# Altium schematic sheet Interfaces.SchDoc
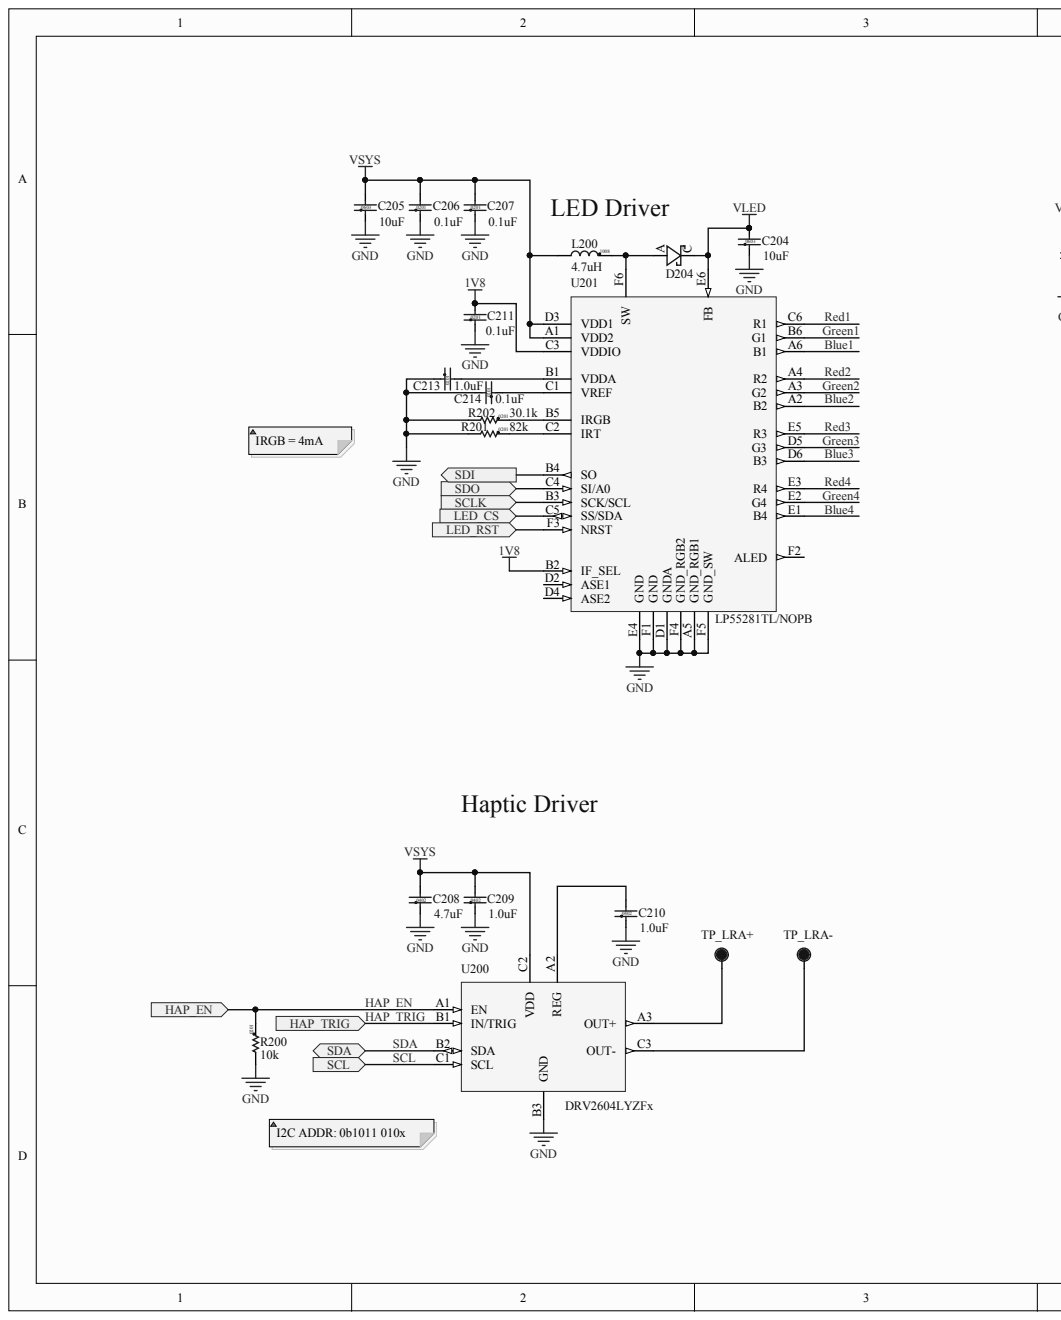
[diagram p0: Interfaces.SchDoc, left]
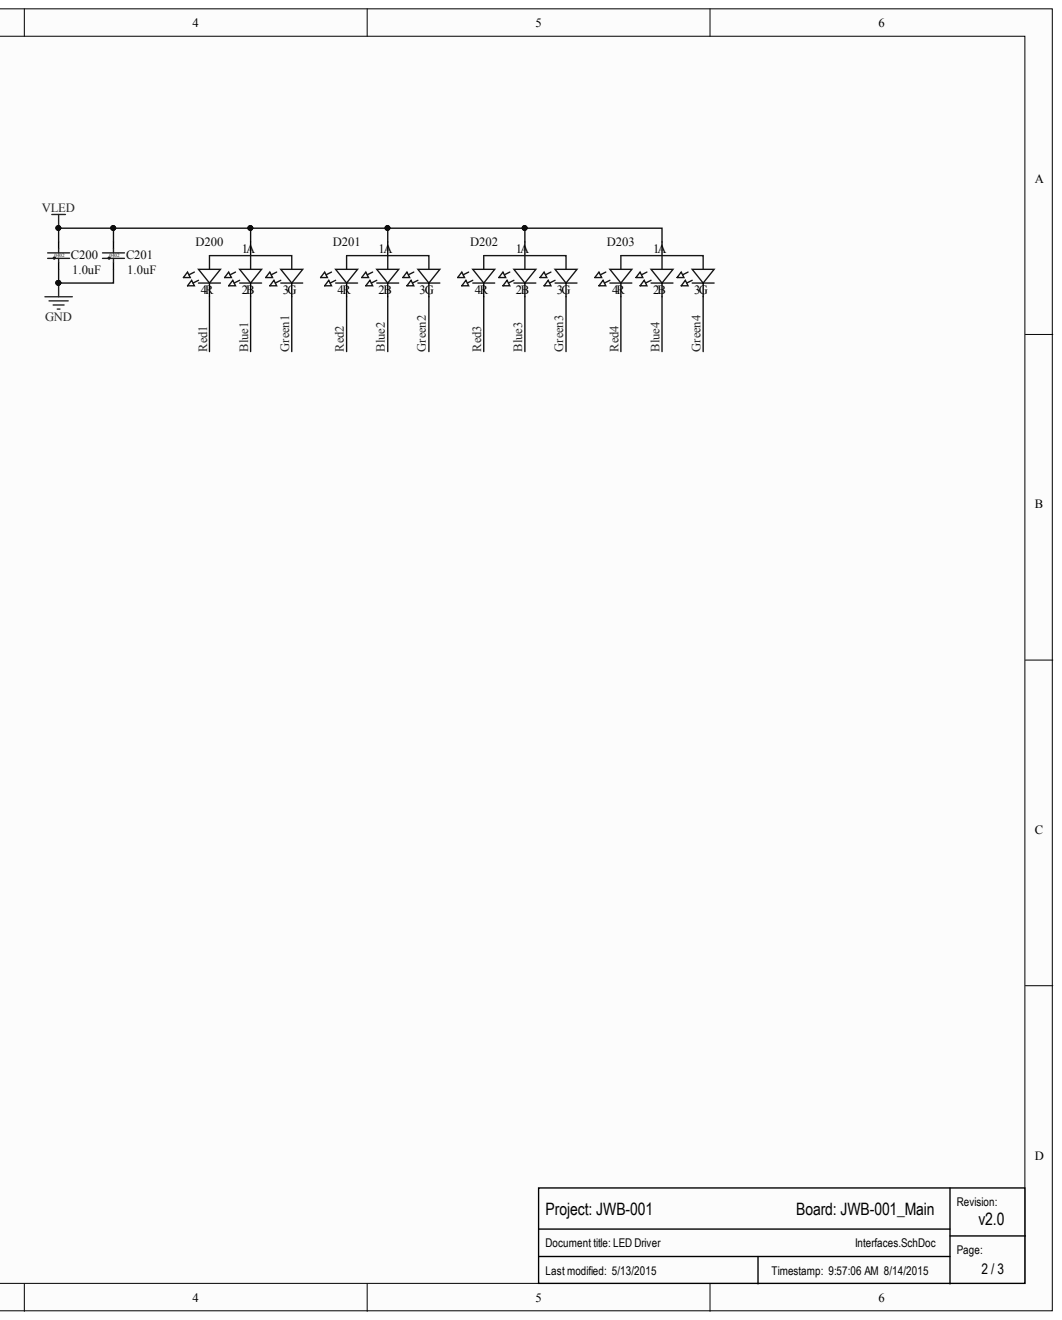
[diagram p1: Interfaces.SchDoc, right]

## Parts
C200  value 1.0uF  Size: 0402  [Cap 0402 HD]  (hidden comment: Cap 0402 1.0uF 10V1.0uF)
C201  value 1.0uF  Size: 0402  [Cap 0402 HD]  (hidden comment: Cap 0402 1.0uF 10V1.0uF)
C204  value 10uF  Size: 0603  [Cap 0603]  (hidden comment: Cap 0603 10uF 10V10uF)
C205  value 10uF  Size: 0603  [Cap 0603]  (hidden comment: Cap 0603 10uF 10V10uF)
C206  value 0.1uF  Size: 0201  [Cap 0201 HD]  (hidden comment: Cap 0201 0.1uF 6.3V0.1uF)
C207  value 0.1uF  Size: 0201  [Cap 0201 HD]  (hidden comment: Cap 0201 0.1uF 6.3V0.1uF)
C208  value 4.7uF  Size: 0402  [Cap 0402 HD]  (hidden comment: Cap 0402 4.7uF 10V4.7uF)
C209  value 1.0uF  Size: 0402  [Cap 0402 HD]  (hidden comment: Cap 0402 1.0uF 10V1.0uF)
C210  value 1.0uF  Size: 0402  [Cap 0402 HD]  (hidden comment: Cap 0402 1.0uF 10V1.0uF)
C211  value 0.1uF  Size: 0201  [Cap 0201 HD]  (hidden comment: Cap 0201 0.1uF 6.3V0.1uF)
C213  value 1.0uF  Size: 0201  [Cap 0201 HD]  (hidden comment: Cap 0201 1.0uF 6.3V1.0uF)
C214  value 0.1uF  Size: 0201  [Cap 0201 HD]  (hidden comment: Cap 0201 0.1uF 6.3V0.1uF)
D200  CLMVB-FKA-CFHEHLCBB7A363  [4-PLCC]  (value not drawn)
D201  CLMVB-FKA-CFHEHLCBB7A363  [4-PLCC]  (value not drawn)
D202  CLMVB-FKA-CFHEHLCBB7A363  [4-PLCC]  (value not drawn)
D203  CLMVB-FKA-CFHEHLCBB7A363  [4-PLCC]  (value not drawn)
D204  MBR120VLSFT3G20V  [SOD-123F_U]  (value not drawn)
L200  value 4.7uH  Size: 1008  [IND 1008]  (hidden comment: Ind 1008 4.7uH 650mA4.7uH)
R200  value 10k  Size: 0201  [RES 0201 HD]  (hidden comment: Res 0201 10k 5%10k)
R201  value 82k  Size: 0201  [RES 0201 HD]  (hidden comment: Res 0201 Generic82k)
R202  value 30.1k  Size: 0201  [RES 0201 HD]  (hidden comment: Res 0201 Generic30.1k)
TP_LRA+  TP  [TP17R]
TP_LRA-  TP  [TP17R]
U200  DRV2604L  Manufacturer P/N: DRV2604LYZFx  [BGA0.5P3x3-9Pin]
U201  LP55281TL  Manufacturer P/N: LP55281TL/NOPB  [BGA0.5P6x6-36Pin]

## Ports
HAP_EN, HAP_TRIG, SCL, SCLK, SDA, SDI, SDO, ~{LED_CS}, ~{LED_RST}

## Nets
1V8: C211.1 U201.B2(IF_SEL) U201.C3(VDDIO)
GND: C200.2 C201.2 C204.2 C205.2 C206.2 C207.2 C208.2 C209.2 C210.2 C211.2 C213.2 C214.1 R200.1 R201.1 R202.1 U200.B3(GND) U201.A5(GND_RGB1) U201.D1(GNDA) U201.E4(GND) U201.F1(GND) U201.F4(GND_RGB2) U201.F5(GND_SW)
VLED: C200.1 C201.1 C204.1 D200.1 D201.1 D202.1 D203.1 D204.C U201.E6(FB)
VSYS: C205.1 C206.1 C207.1 C208.1 C209.1 L200.1 U200.C2(VDD) U201.A1(VDD2) U201.D3(VDD1)
~{LED_CS}: U201.C5(SS/SDA) ; port ~{LED_CS}
~{LED_RST}: U201.F3(NRST) ; port ~{LED_RST}
BLUE1: D200.2 U201.A6(B1)
BLUE2: D201.2 U201.A2(B2)
BLUE3: D202.2 U201.D6(B3)
BLUE4: D203.2 U201.E1(B4)
GREEN1: D200.3 U201.B6(G1)
GREEN2: D201.3 U201.A3(G2)
GREEN3: D202.3 U201.D5(G3)
GREEN4: D203.3 U201.E2(G4)
HAP_EN: R200.2 U200.A1(EN) ; port HAP_EN
HAP_TRIG: U200.B1(IN/TRIG) ; port HAP_TRIG
RED1: D200.4 U201.C6(R1)
RED2: D201.4 U201.A4(R2)
RED3: D202.4 U201.E5(R3)
RED4: D203.4 U201.E3(R4)
SCL: U200.C1(SCL) ; port SCL
SCLK: U201.B3(SCK/SCL) ; port SCLK
SDA: U200.B2(SDA) ; port SDA
SDI: U201.B4(SO) ; port SDI
SDO: U201.C4(SI/A0) ; port SDO
NetC210_1: C210.1 U200.A2(REG)
NetC213_1: C213.1 U201.B1(VDDA)
NetC214_2: C214.2 U201.C1(VREF)
NetD204_A: D204.A L200.2 U201.F6(SW)
NetR201_2: R201.2 U201.C2(IRT)
NetR202_2: R202.2 U201.B5(IRGB)
NetTP_LRA+_1: TP_LRA+.1 U200.A3(OUT+)
NetTP_LRA-_1: TP_LRA-.1 U200.C3(OUT-)

Not connected: U201 D2(ASE1) D4(ASE2) F2(ALED)

## Library data of the parts
C200 C201 C209 C210 = Cap 0402 1.0uF 10V: Cap, Ceramic 1.0uF 10V 10% X5R 0402; Manufacturer: Murata; Manufacturer 2 P/N: C1005X5R0J105K050BB; Manufacturer P/N: GRM155R61A105KE15D; Added By: Jeremy D; Database Table Name: Capacitors; Vendor: Digikey; Vendor P/N: 490-3890-1-ND; Added Date: 2/1/2015; Checked Date: 2/5/2015; Component Kind: Standard; Height: 0.55mm; Manufacturer 2: TDK; Part Number: Cap 0402 1.0uF 10V; Signal Integrity: Cap Semi; Simulation: CAP; Temp Coefficient: X5R; Tolerance: 10%; Voltage: 10V; Checked By: Paul B; Library Name: Rigado_AltiumLib.DbLib
C204 C205 = Cap 0603 10uF 10V: Cap, Ceramimic 10uF 10V 20% X5R 0603; Manufacturer: TDK; Manufacturer 2 P/N: LMK107BBJ106MAHT; Manufacturer P/N: C1608X5R1A106M080AC; Added By: Jeremy D; Database Table Name: Capacitors; Vendor: Digikey; Vendor P/N: 445-6853-1-ND; Added Date: 12/12/2014; Checked Date: 2/27/2015; Component Kind: Standard; Height: 0.9mm; Manufacturer 2: Taiyo Yuden; Part Number: Cap 0603 10uF 10V; Signal Integrity: Cap Semi; Simulation: CAP; Temp Coefficient: X5R; Tolerance: 20%; Voltage: 10V; Checked By: Cam N; Library Name: Rigado_AltiumLib.DbLib
C206 C207 C211 C214 = Cap 0201 0.1uF 6.3V: Cap, Ceramic 0.1uF 6.3V 10% X5R  0201; Manufacturer: Murata; Manufacturer 2 P/N: C0603X5R0J104K030BC; Manufacturer P/N: GRM033R60J104KE19D; Added By: Jeremy D; Database Table Name: Capacitors; Vendor: Digikey; Vendor P/N: 490-3167-1-ND; Added Date: 2/1/2015; Checked Date: 2/27/2015; Component Kind: Standard; Height: 0.33mm; Manufacturer 2: TDK; Part Number: Cap 0201 0.1uF 6.3V; Signal Integrity: Cap Semi; Simulation: CAP; Temp Coefficient: X5R; Tolerance: 10%; Voltage: 6.3V; Checked By: Cam N; Library Name: Rigado_AltiumLib.DbLib
C208 = Cap 0402 4.7uF 10V: Cap, Ceramic 4.7uF 10V 20% X5R 0402; Manufacturer: Samsung; Manufacturer 2 P/N: C1005X5R1A475M050BC; Manufacturer P/N: CL05A475MP5NRNC; Added By: Jeremy D; Database Table Name: Capacitors; Vendor: Digikey; Vendor P/N: 1276-1482-1-ND; Added Date: 2/1/2015; Checked Date: 2/5/2015; Component Kind: Standard; Height: 0.55m; Manufacturer 2: TDK; Part Number: Cap 0402 4.7uF 10V; Signal Integrity: Cap Semi; Simulation: CAP; Temp Coefficient: X5R; Tolerance: 20%; Voltage: 10V; Checked By: Paul B; Library Name: Rigado_AltiumLib.DbLib
C213 = Cap 0201 1.0uF 6.3V: Cap, Ceramic 1.0uF 6.3V 20% X5R  0201; Manufacturer: Samsung; Manufacturer 2 P/N: C0603X5R0J105M030BC; Manufacturer P/N: CL03A105MQ3CSNC; Added By: Jeremy D; Database Table Name: Capacitors; Vendor: Digi-key; Vendor P/N: 1276-1325-1-ND; Added Date: 2/24/2015; Checked Date: 2/27/2015; Component Kind: Standard; Height: 0.33mm; Manufacturer 2: TDK; Part Number: Cap 0201 1.0uF 6.3V; Signal Integrity: Cap Semi; Simulation: CAP; Temp Coefficient: X5R; Tolerance: 20%; Voltage: 6.3V; Checked By: Cam N; Library Name: Rigado_AltiumLib.DbLib
D200-D203 = CLMVB-FKA-CFHEHLCBB7A363: RGB LED SMD, Diffused; Manufacturer: Cree; Added By: Jeremy D; Database Table Name: Diodes; Idc: 20mA, 10mA, 10mA; Voltage Rated: 2.6V, 3.8V, 3.8V; Added Date: 2/20/2015; Checked Date: 2/27/2015; Color: RGB; Component Kind: Standard; Height: 1mm; Manufacturer P/N: CLMVB-FKA-CFHEHLCBB7A363; Package: 4-PLCC; Part Number: CLMVB-FKA-CFHEHLCBB7A363; Size: 2.1mm x 2.1mm; Supplier 1: Mouser; Supplier Part Number 1: 941-CLMVBFKACFHEHLCB; Type: LED; Checked By: Cam N; Library Name: Rigado_AltiumLib.DbLib
D204 = MBR120VLSFT3G: Diode, Schottky, 20V 1A SOD-123F, 340mV Vf @ 1A; Manufacturer: ON Semi; Manufacturer 2 P/N: PMEG2010ER,115; Added By: Jeremy D; Database Table Name: Diodes; Added Date: 3/24/2015; Color: N/A; Component Kind: Standard; Height: 1.1mm; Idc: 1A; Manufacturer 2: NXP; Manufacturer P/N: MBR120VLSFT3G; Package: SOD-123F; Part Number: MBR120VLSFT3G; Size: 3.8mm x 1.8mm; Supplier 1: Digi-key; Supplier Part Number 1: MBR120VLSFT3GOSCT-ND; Type: Schottky; Voltage Rated: 20V; Library Name: LOCAL_Rigado_AltiumLib.DbLib
L200 = Ind 1008 4.7uH 650mA: Inductor, 4.7uH, 1008; Manufacturer: Samsung; Manufacturer 2 P/N: CIG22H4R7MNE; Manufacturer P/N: CIG22B4R7MNE; Added By: Jeremy D; Database Table Name: Inductors; Isat: 650mA; Tolerance: 20%; Vendor: Digikey; Vendor P/N: 1276-6218-1-ND; Added Date: 2/20/2015; Checked Date: 2/27/2015; Component Kind: Standard; Height: 1mm; Manufacturer 2: Samsung; Part Number: Ind 1008 4.7uH 650mA; Checked By: Cam N; Library Name: Rigado_AltiumLib.DbLib
R200 = Res 0201 10k 5%: Resistor 10k ohm 5% 1/20W 0201; Manufacturer: Rohm; Manufacturer 2 P/N: ERJ-1GEJ103C; Manufacturer P/N: MCR006YRTJ103; Added By: Jeremy D; Database Table Name: Resistors; Vendor: Digikey; Vendor P/N: RHM10KCCCT-ND; Added Date: 2/1/2015; Checked Date: 2/5/2015; Component Kind: Standard; Height: 0.26mm; Manufacturer 2: Panasonic; Part Number: Res 0201 10k 5%; Power: 1/20W; Signal Integrity: Res; Simulation: RESISTOR; Tolerance: 5%; Checked By: Paul B; Library Name: Rigado_AltiumLib.DbLib
R201 R202 = Res 0201 Generic: Resistor, 0201; Added By: Jeremy D; Database Table Name: Resistors; Added Date: 12/12/2014; Checked Date: 2/5/2015; Component Kind: Standard; Height: 0.26mm; Part Number: Res 0201 Generic; Signal Integrity: Res; Simulation: RESISTOR; Checked By: Paul B; Library Name: Rigado_AltiumLib.DbLib
TP_LRA+ TP_LRA- = TP17R: Testpoint, Round 1.7mm Dia; Added By: Jeremy D; Database Table Name: Testpoints & Pads; Added Date: 2/1/2015; Checked Date: 2/5/2015; Component Kind: Standard; DNP: DNP; Height: 0; Part Number: TP17R; Size: 1.7mm; Checked By: Paul B; Library Name: LOCAL_Rigado_AltiumLib.DbLib
U200 = DRV2604L: Haptic Driver w/Internal Memory, I2C, DSBGA 9; Manufacturer: Texas Instruments; Added By: Jeremy D; Database Table Name: Interface; MFG Footprint: DSBGA 9 (YZF); Vendor: Arrow; Voltage-Rated: 5.2V; Added Date: 1/30/2015; Checked Date: 2/5/2015; Component Kind: Standard; Controller Interface: I2C; Features: LRA and ERM Haptic Driver w/Memory and Trigger input; Height: .625mm; Packaging: Tape & Reel; Part Number: DRV2604L; Size: 1.47mm x 1.47mm; Checked By: Paul B; Library Name: Rigado_AltiumLib.DbLib
U201 = LP55281TL: Quad RGB LED driver w/integrated boost supply and audio sync; Manufacturer: Texas Instruments; Added By: Jeremy D; Controller Interface: SPI/I2C; Database Table Name: Interface; MFG Footprint: YZR0036; Voltage-Rated: 5.5V; Added Date: 3/24/2015; Checked Date: 5/12/2015; Component Kind: Standard; Features: Integrated LED boost, Audio sync; Height: 0.68mm; Part Number: LP55281TL; Size: 3.013mm x 3.013mm; Supplier 1: Digi-key; Supplier Part Number 1: LP55281TL/NOPBCT-ND; Checked By: Paul B; Library Name: Rigado_AltiumLib.DbLib
